# Altium schematic sheet 08 Camera.SchDoc
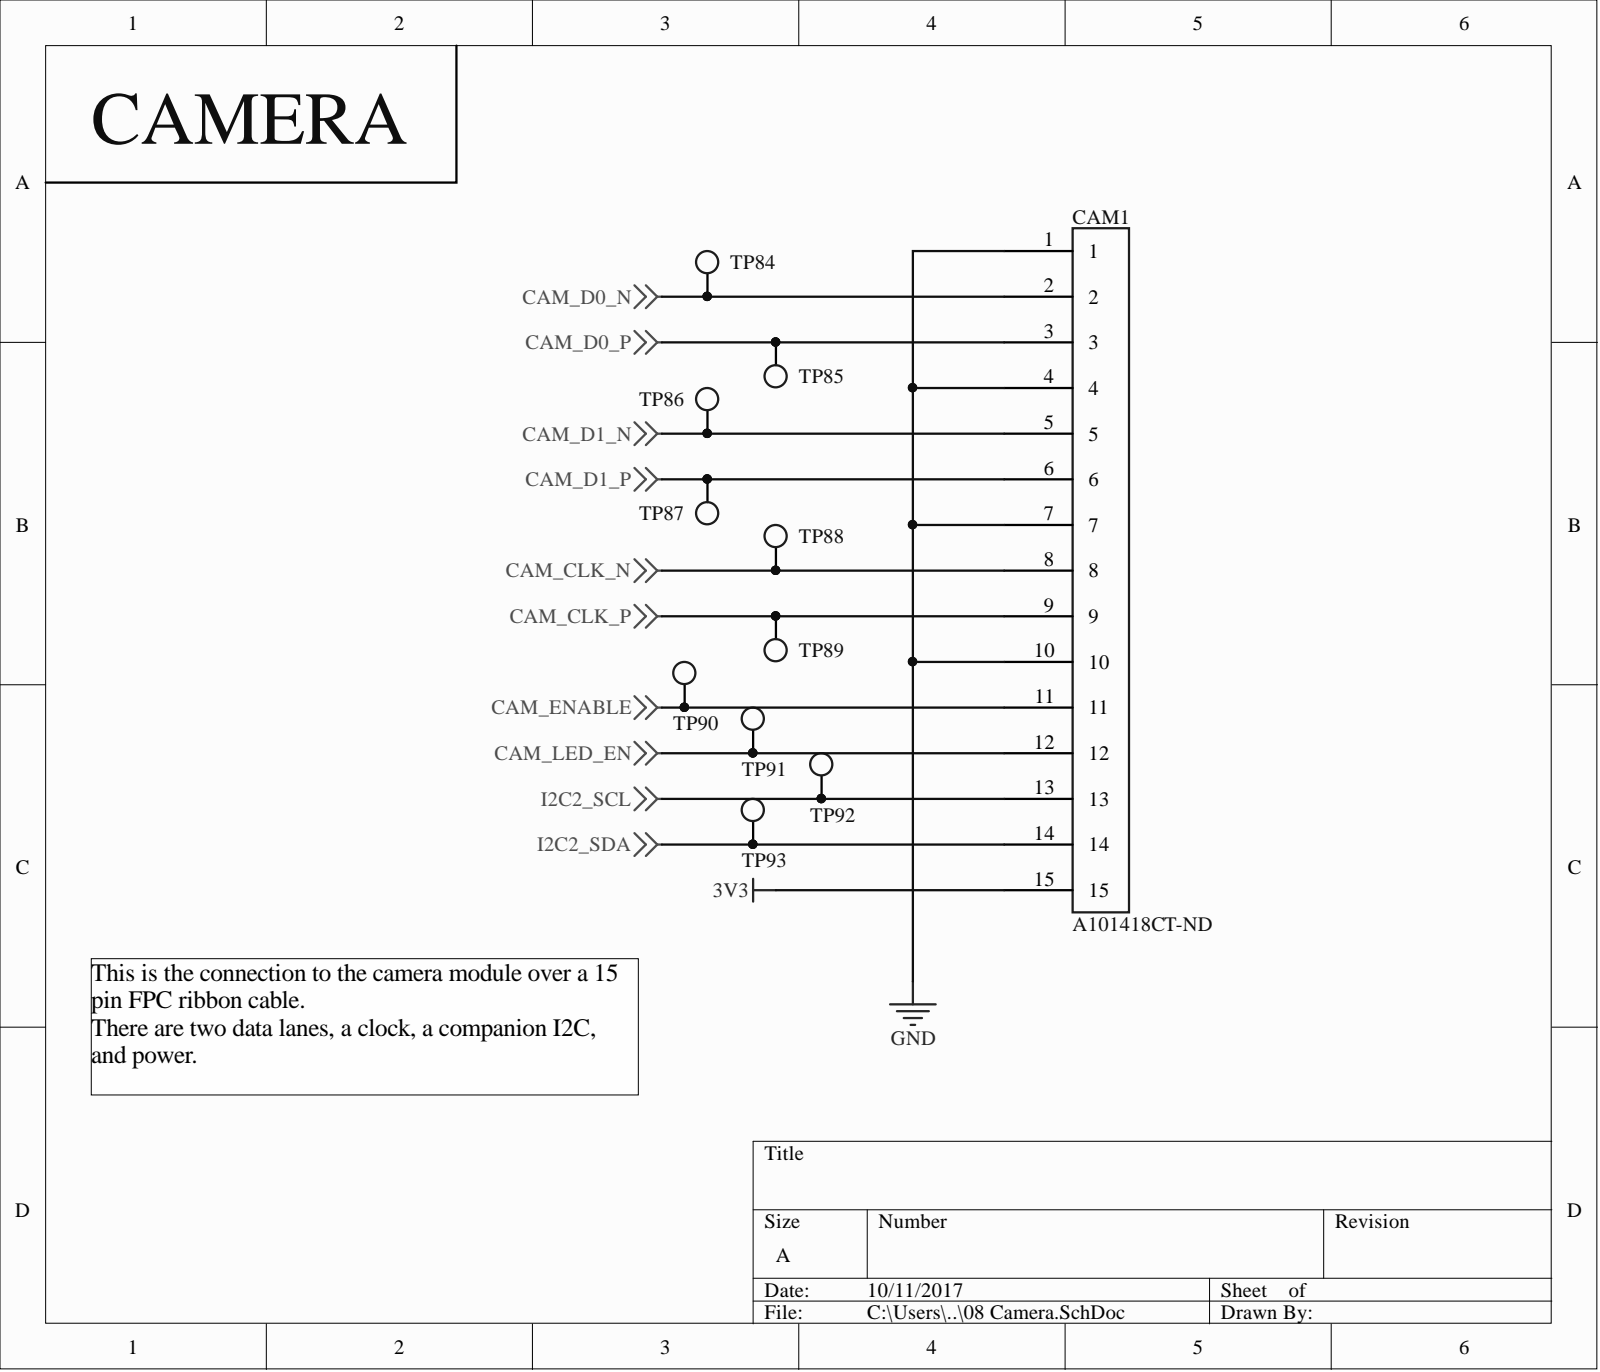
[diagram p0: 08 Camera.SchDoc]

## Parts
CAM1  A101418CT-ND  [ZIF_15pin]
TP84  TP  [TestPoint_1206]
TP85  TP  [TestPoint_1206]
TP86  TP  [TestPoint_1206]
TP87  TP  [TestPoint_1206]
TP88  TP  [TestPoint_1206]
TP89  TP  [TestPoint_1206]
TP90  TP  [TestPoint_1206]
TP91  TP  [TestPoint_1206]
TP92  TP  [TestPoint_1206]
TP93  TP  [TestPoint_1206]

## Nets
3V3: CAM1.15
CAM_CLK_N: CAM1.8 TP88.1
CAM_CLK_P: CAM1.9 TP89.1
CAM_D0_N: CAM1.2 TP84.1
CAM_D0_P: CAM1.3 TP85.1
CAM_D1_N: CAM1.5 TP86.1
CAM_D1_P: CAM1.6 TP87.1
CAM_ENABLE: CAM1.11 TP90.1
CAM_LED_EN: CAM1.12 TP91.1
GND: CAM1.1 CAM1.4 CAM1.7 CAM1.10
I2C2_SCL: CAM1.13 TP92.1
I2C2_SDA: CAM1.14 TP93.1

## Library data of the parts
CAM1 = A101418CT-ND: CONN FPC VERT 15POS 1.00MM SMD; Manufacturer: TE Connectivity; Manufacturer Part Number: 1-1734248-5; Connector System: Flex-to-Board; Connector Type: Connector,Receptacle,Socket; Current Rating: 1A; Gender: Receptacle; Mating Alignment: Yes (With); Number of Contacts: 15; PCB Mount Alignment: No (Without); PCB Mounting Orientation: Vertical; PCB Mount Retention: With; Pitch: 0.039inch; Shielding: Unshielded; Voltage Rating: 125V; Wire/Cable Type: Flat Flex; Case/Package: SMD/SMT; Component Kind: Standard; ComponentLink1Description: https://datasheet.ciiva.com/672/eng-cd-1734248-e1-672176.pdf; ComponentLink1URL: https://datasheet.ciiva.com/672/eng-cd-1734248-e1-672176.pdf; ComponentLink2Description: https://datasheet.ciiva.com/672/eng-cd-1734248-e1-672177.pdf; ComponentLink2URL: https://datasheet.ciiva.com/672/eng-cd-1734248-e1-672177.pdf; ComponentLink3Description: https://datasheet.ciiva.com/672/eng-cd-1734248-e1-672178.pdf; ComponentLink3URL: https://datasheet.ciiva.com/672/eng-cd-1734248-e1-672178.pdf; ComponentLink4Description: https://datasheet.ciiva.com/7989/eng-ds-1-1773440-6-0506-7989477.pdf; ComponentLink4URL: https://datasheet.ciiva.com/7989/eng-ds-1-1773440-6-0506-7989477.pdf; ComponentLink5Description: https://datasheet.ciiva.com/16660/eng-ds-1-1773440-6-0506-16660185.pdf; ComponentLink5URL: https://datasheet.ciiva.com/16660/eng-ds-1-1773440-6-0506-16660185.pdf; Contact Material: Bronze; Contact Plating: Gold; Depth: 4.6mm; ELV: Compliant; Flammability Rating: UL94 V-0; Height: 7.7mm; Housing Colour: Black; Housing Material: Thermoplastic; Length: 22.4mm; Max Current Rating: 1A; Max Operating Temperature: 185°C; Min Operating Temperature: -4°C; Mount: Surface Mount; Number of Positions: 15; Number of Rows: 1; Orientation: Straight; Package Quantity: 1000; Packaging: Tape and Reel; Pricing 1: 1=1.67, 10=1.515, 25=1.4224, 50=1.3606, 100=1.2988, 250=1.1751, 500=1.0824 (USD); REACH SVHC: Unknown; Sealable: No (Without); Shrouded: Yes (With); Stock 1: 14321; Supplier 1: Digi-Key; Supplier Part Number 1: A101418CT-ND; Termination: SMD/SMT; Width: 4.6mm; Library Name: schlib_truman.SchLib
TP84-TP93 = TP: Testpoint_1206; Manufacturer: TE Connectivity; Manufacturer Part Number: 1625854-4; Resistance: 0mR; Case/Package: 1206; Colour: Natural; Component Kind: Standard; ComponentLink1Description: https://datasheet.ciiva.com/16499/1625854-4-16499923.pdf; ComponentLink1URL: https://datasheet.ciiva.com/16499/1625854-4-16499923.pdf; ComponentLink2Description: https://datasheet.ciiva.com/6439/eng-ds-1773266-a-6439173.pdf; ComponentLink2URL: https://datasheet.ciiva.com/6439/eng-ds-1773266-a-6439173.pdf; ComponentLink3Description: https://datasheet.ciiva.com/6439/eng-ds-1773266-a-6439161.pdf; ComponentLink3URL: https://datasheet.ciiva.com/6439/eng-ds-1773266-a-6439161.pdf; ComponentLink4Description: https://datasheet.ciiva.com/36535/eng-ds-1773266-a-36535790.pdf; ComponentLink4URL: https://datasheet.ciiva.com/36535/eng-ds-1773266-a-36535790.pdf; Depth: 1.6mm; Lead Free: Lead Free; Length: 3.2mm; Max Operating Temperature: 125°C; Military Standard: Not; Min Operating Temperature: -55°C; Package Quantity: 2000; Packaging: Tape and Reel; Pricing 1: 1=0.28, 10=0.261, 25=0.224, 50=0.1902, 100=0.1828, 250=0.1641, 500=0.1567, 1000=0.1306 (USD); Radiation Hardening: No; Stock 1: 23359; Supplier 1: Digi-Key; Supplier Part Number 1: A106146CT-ND; Library Name: schlib_truman.SchLib
